# Altium schematic sheet MCU.SchDoc
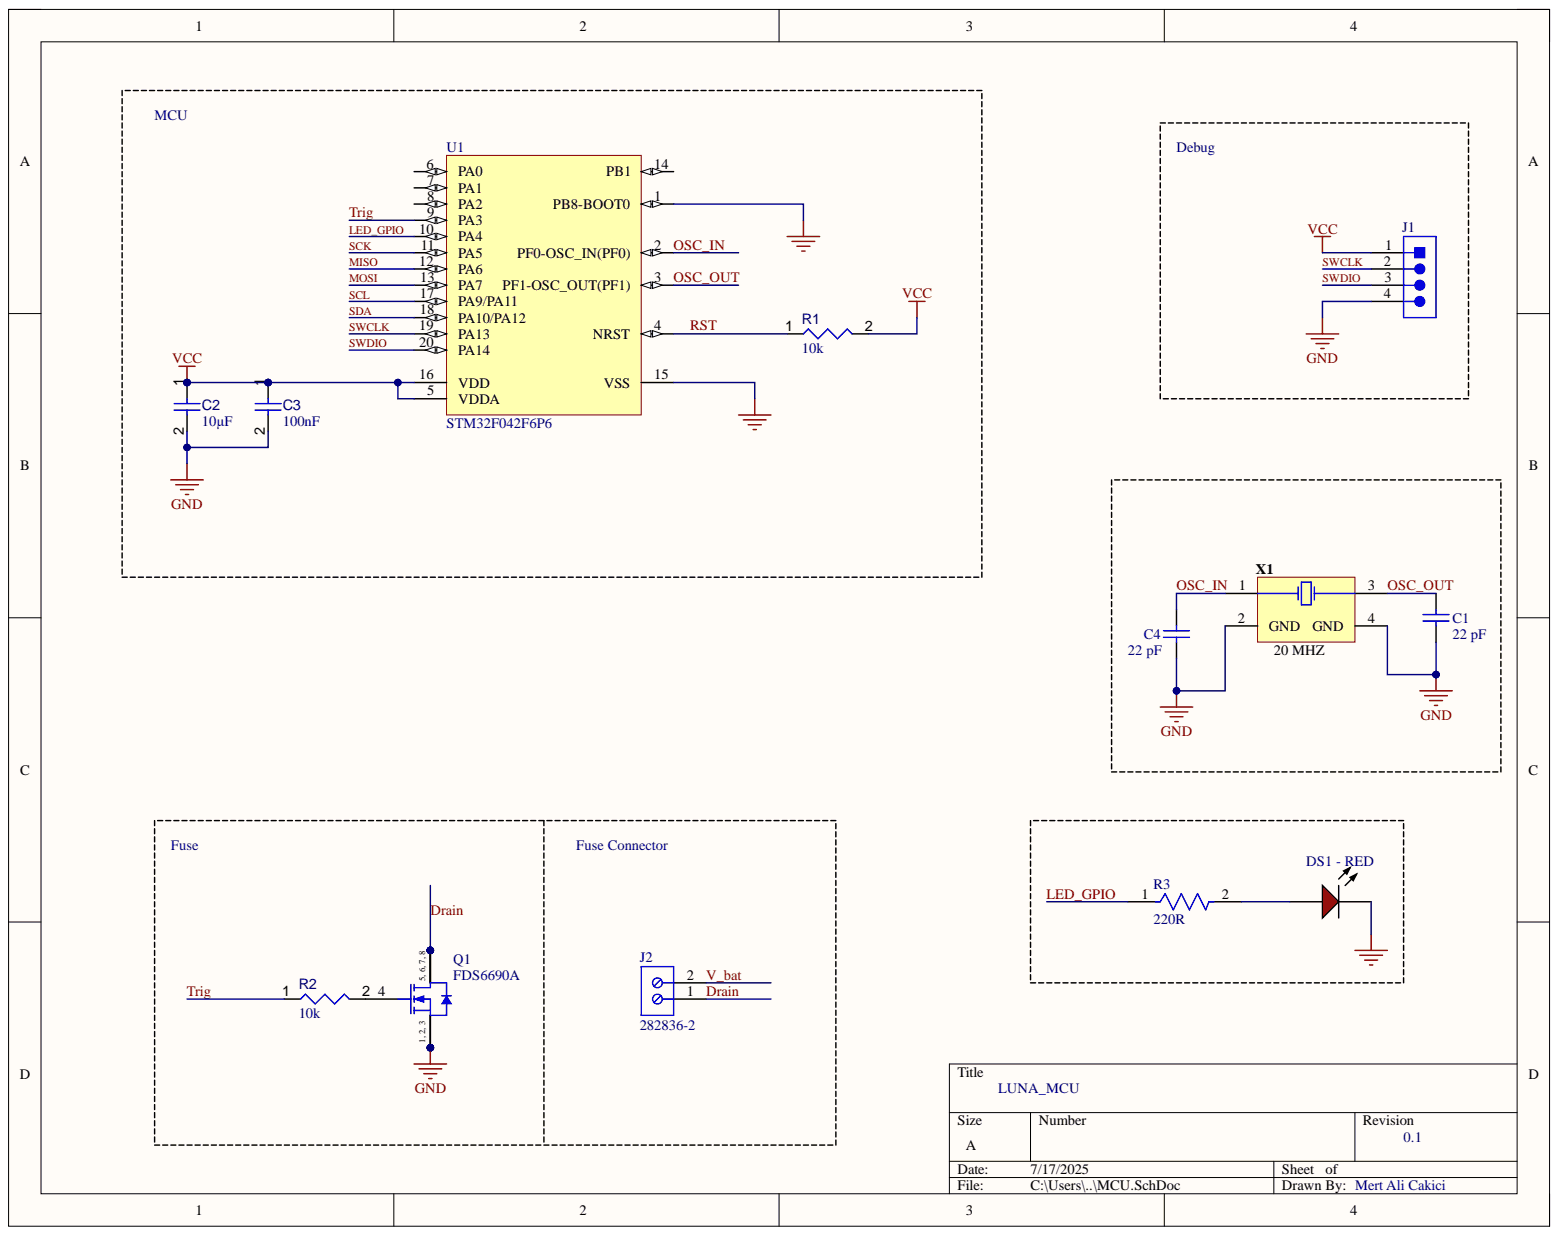
[diagram p0: MCU.SchDoc]

## Parts
C1  22 pF  [CAPC1608X90X35NL10T15]
C2  value 10µF  [CAPC0603(1608)100_L]  (hidden comment: Capacitor 10µF +/-20% 10V 0603)
C3  value 100nF  [CAPC1608X90X40NL10T20]  (hidden comment: Capacitor 100 nF +/-10% 50 V 0603)
C4  22 pF  [CAPC1608X90X35NL10T15]
DS1_-_RED  19-217/R6C-AL1M2VY/3T  [EVRL-0603-2_V]  (value not drawn)
J1  640456-4  [TECO-640456-4]  (value not drawn)
J2  282836-2  [TECO-282836-2_V]
Q1  FDS6690A  [FAIR-SOIC-8_V]
R1  value 10k  [RESC1608X55X25NL10T15]  (hidden comment: Resistor 10k +/-1% 0603 100 mW)
R2  value 10k  [RESC1608X55X25NL10T15]  (hidden comment: Resistor 10k +/-1% 0603 100 mW)
R3  220R  [FP-CRCW0603-e3-MFG]
U1  STM32F042F6P6  [STM-TSSOP20_M]
X1  20 MHZ  [TXC-7B-4_V]

## Nets
GND: C1.1 C2.2 C3.2 C4.1 DS1_-_RED.2 J1.4 Q1.1 Q1.2 Q1.3 U1.1(PB8-BOOT0) U1.15(VSS) X1.2(GND) X1.4(GND)
VCC: C2.1 C3.1 J1.1 R1.2 U1.5(VDDA) U1.16(VDD)
Drain: J2.1 Q1.5 Q1.6 Q1.7 Q1.8
LED_GPIO: R3.1 U1.10(PA4)
MISO: U1.12(PA6)
MOSI: U1.13(PA7)
OSC_IN: C4.2 U1.2(PF0-OSC_IN(PF0)) X1.1
OSC_OUT: C1.2 U1.3(PF1-OSC_OUT(PF1)) X1.3
RST: R1.1 U1.4(NRST)
SCK: U1.11(PA5)
SCL: U1.17(PA9/PA11)
SDA: U1.18(PA10/PA12)
SWCLK: J1.2 U1.19(PA13)
SWDIO: J1.3 U1.20(PA14)
Trig: R2.1 U1.9(PA3)
V_bat: J2.2
NetDS1 - RED_1: DS1_-_RED.1 R3.2
NetQ1_4: Q1.4 R2.2

Not connected: U1 6(PA0) 7(PA1) 8(PA2) 14(PB1)

## Library data of the parts
C1 C4 = CMP-2000-04945-1: Chip Capacitor, 22 pF, +/- 5%, 50 V, -55 to 125 degC, 0603 (1608 Metric), RoHS, Tape and Reel; Manufacturer: Murata; Capacitance: 22pF; Dielectric: C0G; Rated Voltage: 50V; Case Size/Dimension: 0603; Component Kind: Standard; Datasheet URL: https://datasheet.ciiva.com/11786/0900766b807a1cab-11786398.pdf; Datasheet Version: Jul-06; Library Name: Altium Content Vault; Manufacturer URL: http://www.murata.com/; Package Description: 2-Pin Surface Mount Device, Body 1.6 x 0.8 mm; Package Reference: 603; Tolerance (%): ±5%
C2 = CMP-001-00075-4: Chip Capacitor, 10µF +/-20%, 10V, 0603, Thickness 1 mm; Tolerance: 10%; Case/Package: 0603; Component Kind: Standard; Max Operating Temperature: 85°C; Min Operating Temperature: -55°C; Mounting Technology: SMT; Pins: 2; Voltage Rating: 10V; Library Name: Mert ALi Çakıcı
C3 = CMP-001-00017-6: Chip Capacitor, 100 nF, +/- 10%, 50 V, 0603 (1608 Metric); Capacitor Type: Ceramic; Voltage Rating: 50V; Case/Package: 0603; Component Kind: Standard; Max Operating Temperature: 125°C; Min Operating Temperature: -55°C; Mounting Technology: SM; Pins: 2; RoHS Compliant: True; Tolerance: 10%; Library Name: Mert ALi Çakıcı
DS1_-_RED = CMP-007-00004-2: Chip LED, Red, 5 V, 25 mA, -40 to 85 degC, 2-Pin SMD (0603), RoHS, Tape and Reel; Manufacturer: Everlight Electronics; Forward Voltage: 2.2V; Lens Style: Clear; Case/Package: 0603; Colour: Red; Component Kind: Standard; Datasheet URL: https://datasheet.ciiva.com/4688/el-19-217-r6c-al1m2vy-3tpdf-187026-4688713.pdf; Datasheet Version: Rev. 1, 01/2005; Manufacturer URL: http://www.everlight.com/; Mounting Technology: SM; Package Description: 2-Pin Surface Mount Device, Body 1.6 x 0.8 mm; Package Reference: 0603; RoHS Compliant: False; Library Name: Mert ALi Çakıcı
J1 = CMP-2000-05419-1: Male Header, Pitch 2.54 mm, 1 x 4 Position, Height 10.03 mm, Tail Length 3.56 mm, RoHS, Bulk; Manufacturer: TE Connectivity; Agency Approvals: CSA,UL; Angle: Straight; Brand/Series: MTA-100Series; Color, Housing: White; Connector Type: MTA-100; Contact Centerline Spacing: 0.100    in.; Contact Termination Area Plating: Tin; Current, Rating: 5    A; Material, Contact: CopperAlloy; Material, Housing: Polyester; Mount Angle: Vertical; Mounting Hole Size: 0.04    "; Number of Contacts: 4; Pin Spacing: 0.1    "; Primary Type: InterconnectSystem; Special Features: Pinstrip; Standards: RoHSCompliant,ELVCompliant,UL94V-0; Temperature, Operating: -55to+105    degC; Voltage, Rating: 250    V; Color: White; Component Kind: Standard; Contact Mating Area Plating: Tin; Contact Plating: Tin; Contact Shape: Square; Datasheet URL: https://datasheet.ciiva.com/16286/eng-ds-82056-mta-0608-16286228.pdf; Datasheet Version: Dec-14; Flammability Rating: UL94V-0; Length, Overall: 0.4    "; Length, Pin: 0.14    "; Length, Terminal Post: 0.14    in.; Library Name: Altium Content Vault; Manufacturer URL: http://www.te.com/; Mounting Type: PCMount; Number of Positions: 4; Number of Rows: 1; Size, Post: 0.025    in.; Termination: Solder; Type: PCBPlug
J2 = CMP-1684-00017-1: Female Header, Pitch 5 mm, 1 x 2 Position, Height 10 mm, Tail Length 3.5 mm, Rohs, Bulk; Manufacturer: TE Connectivity; 3D Model: 282836-2.pdf; Clamp Material - Plating: Brass-NickelPlated; Current: 13.5A; Dynamic Catalog: BuchananSeries; Featured Product: EurostyleTerminalBlockConnectors; Mating Orientation: HorizontalwithBoard; Number of Levels: 1; Other Names: 5ULV-02B  A98076; Pitch: 0.197"(5.00mm); Positions Per Level: 2; Product Training Modules: Wire-to-BoardEurostyleTerminalBlocks; Screw Thread: M3; Torque - Screw: 0.68Nm(6Lb-In); Voltage: 300V; Wire Gauge: 16-30AWG; Wire Termination: Screw-RisingCageClamp; Category: Connectors,Interconnects; Color: Green; Component Kind: Standard; Contact Material - Plating: Brass-TinPlated; Datasheet URL: http://www.te.com.cn/commerce/DocumentDelivery/DDEController?Action=showdoc&DocId=Catalog+Section%7F1308389_EUROSTYLE_TERMINAL_BLOCKS%7F0607%7Fpdf%7FEnglish%7FENG_CS_1308389_EUROSTYLE_TERMINAL_BLOCKS_0607.pdf%7F282836-2; Family: TerminalBlocks-WiretoBoard; Features: Interlocking(Side); Housing Material: Polyamide(PA66),Nylon6/6; Library Name: Altium Content Vault; Manufacturer URL: http://www.te.com; Mounting Type: ThroughHole; Package Description: Female Header, Pitch 5 mm, 1 x 2 Position, Height 10 mm, Tail Length 3.5 mm; Package Reference: THD; Packaging: Bulk; RoHS Information: 282836-2StatementofCompliance; Screw Material - Plating: Brass-NickelPlated; Series: Buchanan; Standard Package: 300
Q1 = CMP-2000-06260-1: Single N-Channel, Logic-Level, PowerTrench(R) MOSFET, 11 A, 30 V, -55 to 150 degC, 8-Pin SOIC (SO-8), RoHS, Tape and Reel; Manufacturer: Fairchild Semiconductor; Channel Mode: Enhancement; Channel Type: N; Maximum Continuous Drain Current: 11A; Maximum Drain Source Resistance: 0.013Ω; Maximum Drain Source Voltage: 30V; Maximum Gate Source Voltage: ±20V; Maximum Power Dissipation: 2.5W; Minimum Gate Threshold Voltage: 1V; Number of Elements per Chip: 1; Transistor Material: Si; Typical Gate Charge @ Vgs: 12nC@5V; Typical Input Capacitance @ Vds: 1205pF@15V; Typical Turn-Off Delay Time: 28ns; Typical Turn-On Delay Time: 9ns; Category: PowerMOSFET; Component Kind: Standard; Datasheet URL: https://www.fairchildsemi.com/datasheets/FD/FDS6690A.pdf; Datasheet Version: Rev. E1, 02/2007; Dimensions: 5x4x1.5mm; Height: 1.5mm; Length: 5mm; Library Name: Altium Content Vault; Manufacturer URL: https://www.fairchildsemi.com/; Maximum Operating Temperature: +150°C; Minimum Operating Temperature: -55°C; Mounting Technology: SurfaceMount; Mounting Type: SurfaceMount; Package Description: 8-Pin Small Outline Integrated Circuit, Body 4.9 x 3.9 mm, Pitch 1.27 mm; Package Reference: SOIC-8; Package Type: SOIC; Package URL: https://www.fairchildsemi.com/package-drawings/M0/M08A.pdf; Pin Count: 8; Transistor Configuration: Single; Width: 4mm
R1 R2 = CMP-009-00017-5: Chip Resistor, 10 KOhm, +/- 1%, 01 W, -55 to 155 degC, 0603 (1608 Metric); Power: 100mW; Voltage Rating: 50V; Case/Package: 0603; Component Kind: Standard; Max Operating Temperature: 155°C; Min Operating Temperature: -55°C; Mounting Technology: SM; Pins: 2; RoHS Compliant: True; Tolerance: 1%; Library Name: Mert ALi Çakıcı
R3 = CMP-2000-03085-2: RES Thick Film, 220Ω, 1%, 0.1W, 100ppm/°C, 0603; Max Power Dissipation: 100mW; Voltage Rating: 75V; Case Code (Imperial): 0603; Case Code (Metric): 1608; Case/Package: 0603; Component Kind: Standard; Composition: Thick Film; Contact Plating: Tin; Depth: 0.85mm; Features: Automotive AEC-Q200; Height: 0.45mm; Lead Free: Lead Free; Length: 1.55mm; Library Name: Altium Content Vault; Max Operating Temperature: 155°C; Military Standard: Not; Min Operating Temperature: -55°C; Number of Terminations: 2; Package Quantity: 10; Packaging: Tape and Reel; Power Rating: 100mW; Radiation Hardening: No; Resistance: 220R; Temperature Coefficient: 100ppm/°C; Termination: SMD/SMT; Tolerance: 1%; Voltage Rating (DC): 75V; Weight: 7.1E-05oz; Width: 0.033inch
U1 = CMP-0304-00108-2: ARM Cortex-M0 32-bit MCU, 32 KB Flash, 6 KB Internal RAM, 16 I/Os, 20-Pin TSSOP, -40 to 85 degC, Tube; Manufacturer: STMicroelectronics; Component Kind: Standard; ComponentLink1Description: Manufacturer URL; ComponentLink1URL: http://www.st.com/; ComponentLink2Description: Datasheet; ComponentLink2URL: http://www.st.com/st-web-ui/static/active/en/resource/technical/document/datasheet/DM00105814.pdf; Core: ARM Cortex-M0; DatasheetVersion: Rev. 5; Library Name: Altium Content Vault; Mounting Technology: Surface Mount; Package Description: 20-Pin Thin Shrink Small Outline Package, Body 6.5 x 4.4 mm, Pitch 0.65 mm; Package Reference: TSSOP20; PartNumber: STM32F042F6P6
X1 = CMP-003-00011-2: SMD Seam Sealing Crystal, 24 MHz, +/- 30 ppm, 18 pF, -10 to 70 degC, 4-Pin SMD, RoHS, Tape and Reel; Manufacturer: TXC; ESR: 40; Frequency: 24MHz; Frequency Tolerance: 30ppm; Load Capacitance: 18pF; Resistance: 40Ohm; Case/Package: 7B-4; Component Kind: Standard; Datasheet URL: http://www.txccorp.com/download/products/quartz_crystals/2015TXC_7B_19.pdf; Datasheet Version: Jul-15; Max Operating Temperature: 70°C; Min Operating Temperature: -20°C; Mounting Technology: SM; Package Description: 4-Pin Surface Mount Device, Body 5 x 3.2 mm, Pitch 3.7 mm; Package Quantity: 1000; Package Reference: 7B-4; Packaging: TapeandReel; Pins: 4; RoHS Compliant: True; Tolerance: 30ppm; Library Name: Mert ALi Çakıcı
